# Altium schematic sheet [04] - USER IO, LEDs.SchDoc("[04] - USER IO, LEDs")
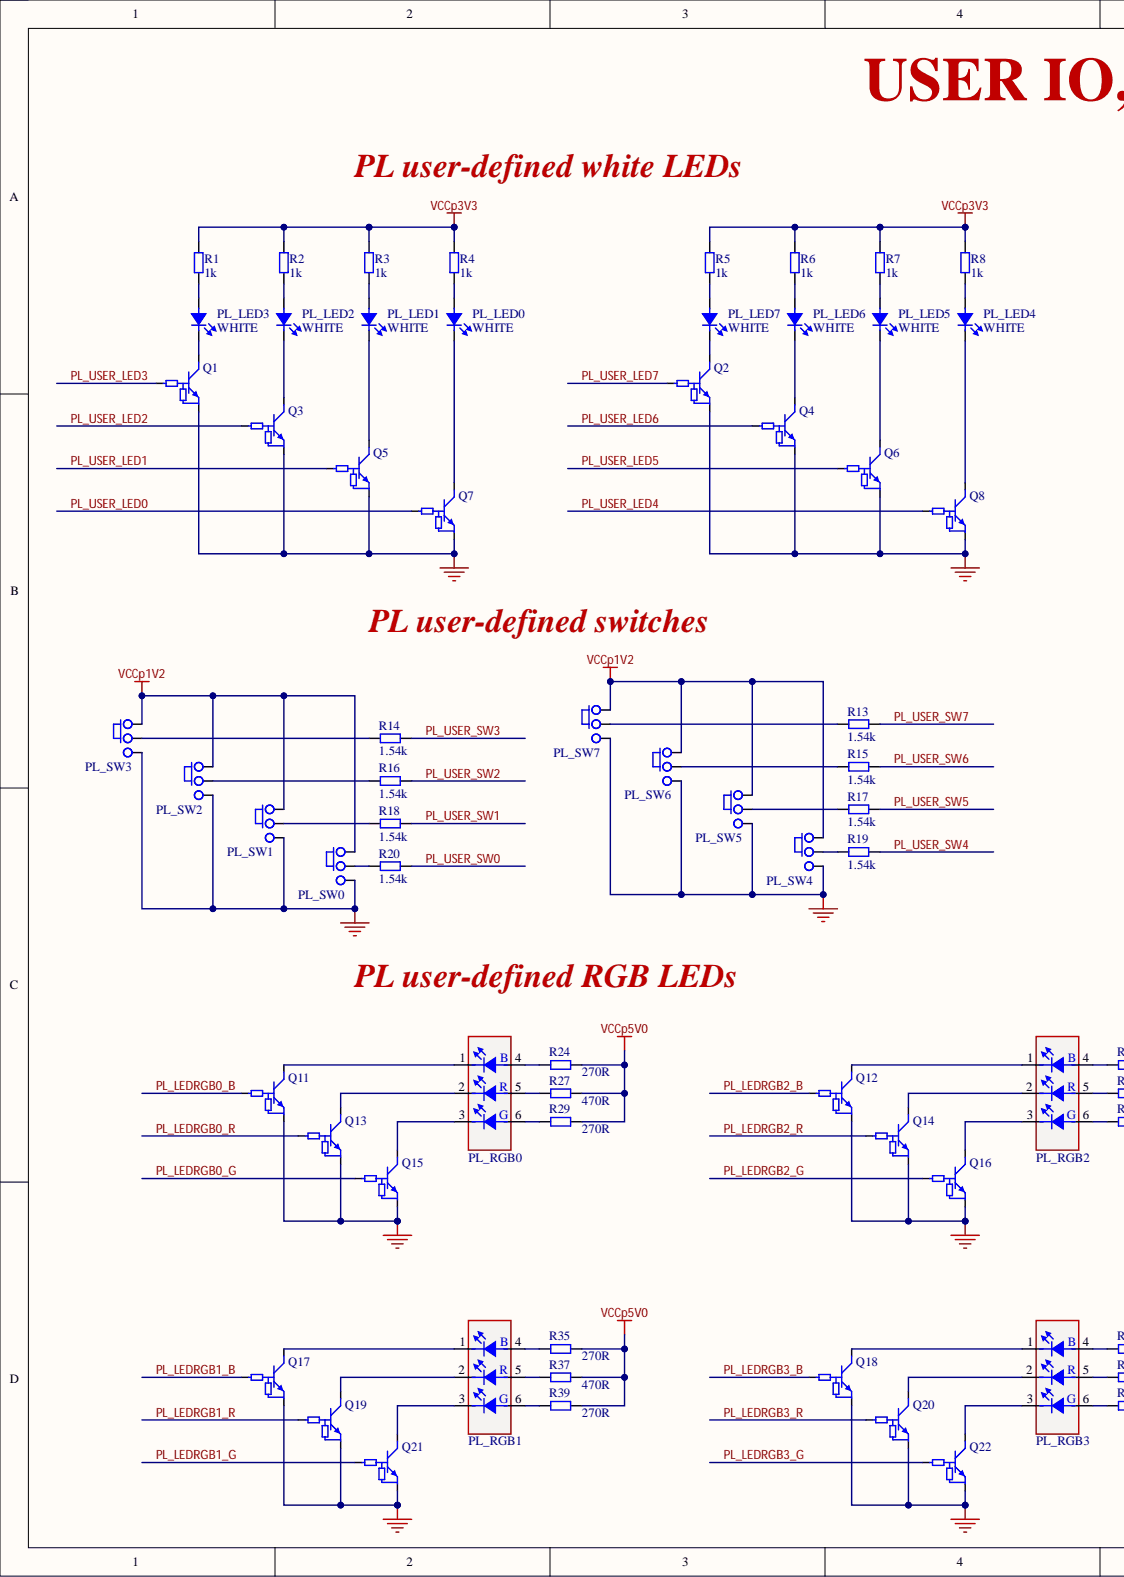
[diagram p0: [04] - USER IO, LEDs.SchDoc, left]
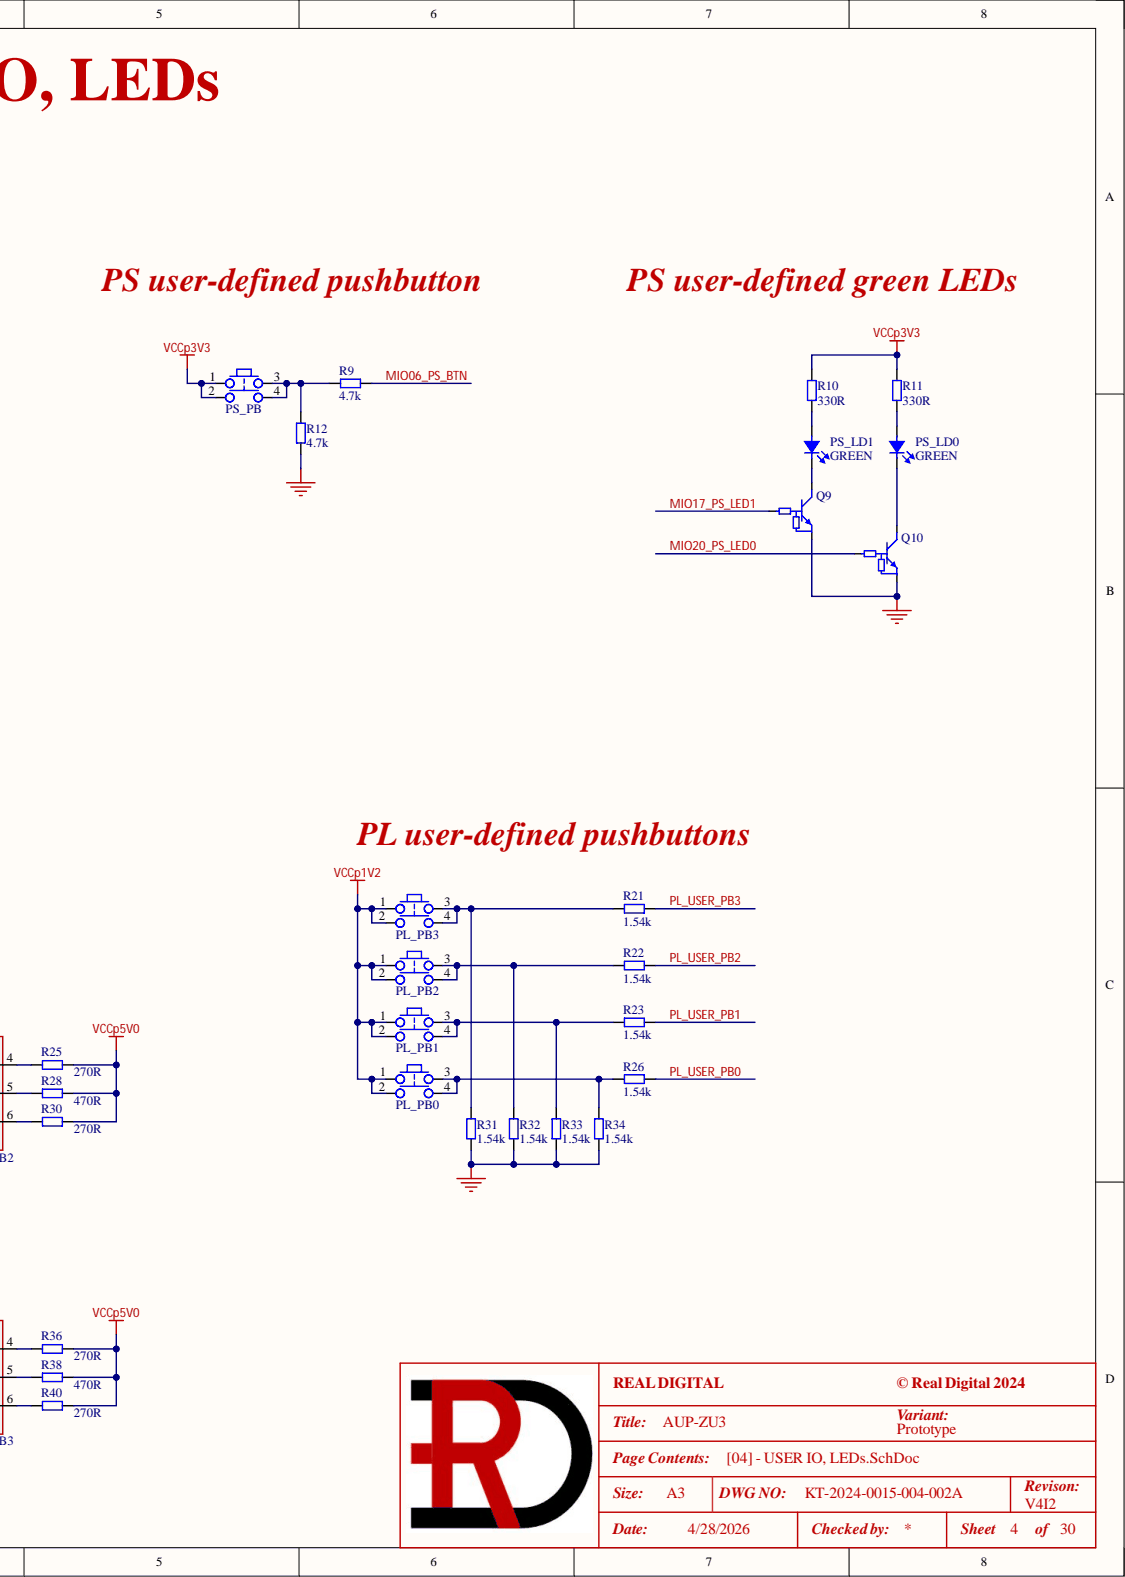
[diagram p1: [04] - USER IO, LEDs.SchDoc, right]

## Parts
PL_LED0  WHITE  [LD0603_White]
PL_LED1  WHITE  [LD0603_White]
PL_LED2  WHITE  [LD0603_White]
PL_LED3  WHITE  [LD0603_White]
PL_LED4  WHITE  [LD0603_White]
PL_LED5  WHITE  [LD0603_White]
PL_LED6  WHITE  [LD0603_White]
PL_LED7  WHITE  [LD0603_White]
PL_PB0  PTA-142  [PTA-142]  (value not drawn)
PL_PB1  PTA-142  [PTA-142]  (value not drawn)
PL_PB2  PTA-142  [PTA-142]  (value not drawn)
PL_PB3  PTA-142  [PTA-142]  (value not drawn)
PL_RGB0  VS NRD8  [LD_RGB_NRD8]  (value not drawn)
PL_RGB1  VS NRD8  [LD_RGB_NRD8]  (value not drawn)
PL_RGB2  VS NRD8  [LD_RGB_NRD8]  (value not drawn)
PL_RGB3  VS NRD8  [LD_RGB_NRD8]  (value not drawn)
PL_SW0  Switch  [SW-SS12F20G4-10.5-HA-G]  (value not drawn)
PL_SW1  Switch  [SW-SS12F20G4-10.5-HA-G]  (value not drawn)
PL_SW2  Switch  [SW-SS12F20G4-10.5-HA-G]  (value not drawn)
PL_SW3  Switch  [SW-SS12F20G4-10.5-HA-G]  (value not drawn)
PL_SW4  Switch  [SW-SS12F20G4-10.5-HA-G]  (value not drawn)
PL_SW5  Switch  [SW-SS12F20G4-10.5-HA-G]  (value not drawn)
PL_SW6  Switch  [SW-SS12F20G4-10.5-HA-G]  (value not drawn)
PL_SW7  Switch  [SW-SS12F20G4-10.5-HA-G]  (value not drawn)
PS_LD0  GREEN  [LD0603_Green]
PS_LD1  GREEN  [LD0603_Green]
PS_PB  PTA-142  [PTA-142]  (value not drawn)
Q1  DTC043ZEBTL  [SC89-EMT3F]  (value not drawn)
Q2  DTC043ZEBTL  [SC89-EMT3F]  (value not drawn)
Q3  DTC043ZEBTL  [SC89-EMT3F]  (value not drawn)
Q4  DTC043ZEBTL  [SC89-EMT3F]  (value not drawn)
Q5  DTC043ZEBTL  [SC89-EMT3F]  (value not drawn)
Q6  DTC043ZEBTL  [SC89-EMT3F]  (value not drawn)
Q7  DTC043ZEBTL  [SC89-EMT3F]  (value not drawn)
Q8  DTC043ZEBTL  [SC89-EMT3F]  (value not drawn)
Q9  DTC043ZEBTL  [SC89-EMT3F]  (value not drawn)
Q10  DTC043ZEBTL  [SC89-EMT3F]  (value not drawn)
Q11  DTC043ZEBTL  [SC89-EMT3F]  (value not drawn)
Q12  DTC043ZEBTL  [SC89-EMT3F]  (value not drawn)
Q13  DTC043ZEBTL  [SC89-EMT3F]  (value not drawn)
Q14  DTC043ZEBTL  [SC89-EMT3F]  (value not drawn)
Q15  DTC043ZEBTL  [SC89-EMT3F]  (value not drawn)
Q16  DTC043ZEBTL  [SC89-EMT3F]  (value not drawn)
Q17  DTC043ZEBTL  [SC89-EMT3F]  (value not drawn)
Q18  DTC043ZEBTL  [SC89-EMT3F]  (value not drawn)
Q19  DTC043ZEBTL  [SC89-EMT3F]  (value not drawn)
Q20  DTC043ZEBTL  [SC89-EMT3F]  (value not drawn)
Q21  DTC043ZEBTL  [SC89-EMT3F]  (value not drawn)
Q22  DTC043ZEBTL  [SC89-EMT3F]  (value not drawn)
R1  1k  [R0402]
R2  1k  [R0402]
R3  1k  [R0402]
R4  1k  [R0402]
R5  1k  [R0402]
R6  1k  [R0402]
R7  1k  [R0402]
R8  1k  [R0402]
R9  4.7k  [R0402]
R10  330R  [R0402]
R11  330R  [R0402]
R12  4.7k  [R0402]
R13  1.54k  [R0402]
R14  1.54k  [R0402]
R15  1.54k  [R0402]
R16  1.54k  [R0402]
R17  1.54k  [R0402]
R18  1.54k  [R0402]
R19  1.54k  [R0402]
R20  1.54k  [R0402]
R21  1.54k  [R0402]
R22  1.54k  [R0402]
R23  1.54k  [R0402]
R24  270R  [R0402]
R25  270R  [R0402]
R26  1.54k  [R0402]
R27  470R  [R0402]
R28  470R  [R0402]
R29  270R  [R0402]
R30  270R  [R0402]
R31  1.54k  [R0402]
R32  1.54k  [R0402]
R33  1.54k  [R0402]
R34  1.54k  [R0402]
R35  270R  [R0402]
R36  270R  [R0402]
R37  470R  [R0402]
R38  470R  [R0402]
R39  270R  [R0402]
R40  270R  [R0402]

## Nets
GND: PL_SW0.3 PL_SW1.3 PL_SW2.3 PL_SW3.3 PL_SW4.3 PL_SW5.3 PL_SW6.3 PL_SW7.3 Q1.GND Q2.GND Q3.GND Q4.GND Q5.GND Q6.GND Q7.GND Q8.GND Q9.GND Q10.GND Q11.GND Q12.GND Q13.GND Q14.GND Q15.GND Q16.GND Q17.GND Q18.GND Q19.GND Q20.GND Q21.GND Q22.GND R12.1 R31.1 R32.1 R33.1 R34.1
VCCp1V2: PL_PB0.1 PL_PB0.2 PL_PB1.1 PL_PB1.2 PL_PB2.1 PL_PB2.2 PL_PB3.1 PL_PB3.2 PL_SW0.1 PL_SW1.1 PL_SW2.1 PL_SW3.1 PL_SW4.1 PL_SW5.1 PL_SW6.1 PL_SW7.1
VCCp3V3: PS_PB.1 PS_PB.2 R1.2 R2.2 R3.2 R4.2 R5.2 R6.2 R7.2 R8.2 R10.2 R11.2
VCCp5V0: R24.2 R25.2 R27.2 R28.2 R29.2 R30.2 R35.2 R36.2 R37.2 R38.2 R39.2 R40.2
MIO06_PS_BTN: R9.2
MIO17_PS_LED1: Q9.IN
MIO20_PS_LED0: Q10.IN
PL_LEDRGB0_B: Q11.IN
PL_LEDRGB0_G: Q15.IN
PL_LEDRGB0_R: Q13.IN
PL_LEDRGB1_B: Q17.IN
PL_LEDRGB1_G: Q21.IN
PL_LEDRGB1_R: Q19.IN
PL_LEDRGB2_B: Q12.IN
PL_LEDRGB2_G: Q16.IN
PL_LEDRGB2_R: Q14.IN
PL_LEDRGB3_B: Q18.IN
PL_LEDRGB3_G: Q22.IN
PL_LEDRGB3_R: Q20.IN
PL_USER_LED0: Q7.IN
PL_USER_LED1: Q5.IN
PL_USER_LED2: Q3.IN
PL_USER_LED3: Q1.IN
PL_USER_LED4: Q8.IN
PL_USER_LED5: Q6.IN
PL_USER_LED6: Q4.IN
PL_USER_LED7: Q2.IN
PL_USER_PB0: R26.2
PL_USER_PB1: R23.2
PL_USER_PB2: R22.2
PL_USER_PB3: R21.2
PL_USER_SW0: R20.2
PL_USER_SW1: R18.2
PL_USER_SW2: R16.2
PL_USER_SW3: R14.2
PL_USER_SW4: R19.2
PL_USER_SW5: R17.2
PL_USER_SW6: R15.2
PL_USER_SW7: R13.2
NetPL_LED0_A: PL_LED0.A R4.1
NetPL_LED0_K: PL_LED0.K Q7.OUT
NetPL_LED1_A: PL_LED1.A R3.1
NetPL_LED1_K: PL_LED1.K Q5.OUT
NetPL_LED2_A: PL_LED2.A R2.1
NetPL_LED2_K: PL_LED2.K Q3.OUT
NetPL_LED3_A: PL_LED3.A R1.1
NetPL_LED3_K: PL_LED3.K Q1.OUT
NetPL_LED4_A: PL_LED4.A R8.1
NetPL_LED4_K: PL_LED4.K Q8.OUT
NetPL_LED5_A: PL_LED5.A R7.1
NetPL_LED5_K: PL_LED5.K Q6.OUT
NetPL_LED6_A: PL_LED6.A R6.1
NetPL_LED6_K: PL_LED6.K Q4.OUT
NetPL_LED7_A: PL_LED7.A R5.1
NetPL_LED7_K: PL_LED7.K Q2.OUT
NetPL_PB0_3: PL_PB0.3 PL_PB0.4 R26.1 R34.2
NetPL_PB1_3: PL_PB1.3 PL_PB1.4 R23.1 R33.2
NetPL_PB2_3: PL_PB2.3 PL_PB2.4 R22.1 R32.2
NetPL_PB3_3: PL_PB3.3 PL_PB3.4 R21.1 R31.2
NetPL_RGB0_1: PL_RGB0.1 Q11.OUT
NetPL_RGB0_2: PL_RGB0.2 Q13.OUT
NetPL_RGB0_3: PL_RGB0.3 Q15.OUT
NetPL_RGB0_4: PL_RGB0.4 R24.1
NetPL_RGB0_5: PL_RGB0.5 R27.1
NetPL_RGB0_6: PL_RGB0.6 R29.1
NetPL_RGB1_1: PL_RGB1.1 Q17.OUT
NetPL_RGB1_2: PL_RGB1.2 Q19.OUT
NetPL_RGB1_3: PL_RGB1.3 Q21.OUT
NetPL_RGB1_4: PL_RGB1.4 R35.1
NetPL_RGB1_5: PL_RGB1.5 R37.1
NetPL_RGB1_6: PL_RGB1.6 R39.1
NetPL_RGB2_1: PL_RGB2.1 Q12.OUT
NetPL_RGB2_2: PL_RGB2.2(R) Q14.OUT
NetPL_RGB2_3: PL_RGB2.3(G) Q16.OUT
NetPL_RGB2_4: PL_RGB2.4 R25.1
NetPL_RGB2_5: PL_RGB2.5 R28.1
NetPL_RGB2_6: PL_RGB2.6 R30.1
NetPL_RGB3_1: PL_RGB3.1 Q18.OUT
NetPL_RGB3_2: PL_RGB3.2(R) Q20.OUT
NetPL_RGB3_3: PL_RGB3.3(G) Q22.OUT
NetPL_RGB3_4: PL_RGB3.4 R36.1
NetPL_RGB3_5: PL_RGB3.5 R38.1
NetPL_RGB3_6: PL_RGB3.6 R40.1
NetPL_SW0_2: PL_SW0.2 R20.1
NetPL_SW1_2: PL_SW1.2 R18.1
NetPL_SW2_2: PL_SW2.2 R16.1
NetPL_SW3_2: PL_SW3.2 R14.1
NetPL_SW4_2: PL_SW4.2 R19.1
NetPL_SW5_2: PL_SW5.2 R17.1
NetPL_SW6_2: PL_SW6.2 R15.1
NetPL_SW7_2: PL_SW7.2 R13.1
NetPS_LD0_A: PS_LD0.A R11.1
NetPS_LD0_K: PS_LD0.K Q10.OUT
NetPS_LD1_A: PS_LD1.A R10.1
NetPS_LD1_K: PS_LD1.K Q9.OUT
NetPS_PB_3: PS_PB.3 PS_PB.4 R9.1 R12.2

## Library data of the parts
PL_LED0-PL_LED7 = LED_WHITE: LED WHITE 0603; Manufacturer 1: Victory Electronics; Manufacturer 1 Part Number: VS 2WC7M.325; .Checked_PCB: AC; .Creator: RV; C P/N: RD-121-0010; KT P/N: MT-121-0002; LoadStatus: Load; Category: Led; Component Kind: Standard; Library Name: KT-RealDigital.IntLib; .Checked_SCH: AC
PL_PB0-PL_PB3 PS_PB = Tact Switch: SWITCH TACTILE SPST-NO, 4.50mm x 4.50mm; Manufacturer 1: Pacer Technology Co., Ltd; Manufacturer 2 Part Number: TSXH-2GL; .Checked_PCB: RV; C P/N: 153-0059; LoadStatus: Load; Category: Tactile Switches; Component Kind: Standard; Library Name: KT-RealDigital.IntLib; Manufacturer 1 Part Number: PTA-142; .Checked_SCH: RV; .Creator: RV
PL_RGB0-PL_RGB3 = LED_RGB: LED RGB; Manufacturer 1: Victory Electronics; .Checked_PCB: RV; C P/N: 121-0264; LoadStatus: Load; Category: Led; Component Kind: Standard; Library Name: KT-RealDigital.IntLib; Manufacturer 1 Part Number: VS NRD8; .Checked_SCH: RV; .Creator: RV
PL_SW0-PL_SW7 = Slide_Switch_Long_Leads: Slide Switch Long Leads (7.35mm), SPDT, Thru Hole,; Manufacturer 1: SWITRONIC INDUSTRIAL CORP.; Manufacturer 1 Part Number: SS12F20G4-10.5-HA-G; .Checked_PCB: AC; .Creator: RV; C P/N: RD-153-0002; LoadStatus: Load; Category: Switches; Component Kind: Standard; Library Name: KT-RealDigital.IntLib; .Checked_SCH: AC
PS_LD0 PS_LD1 = LED_GREEN: LED GREEN 0603; Manufacturer 1: Everlight Electronics CO., LTD; Manufacturer 1 Part Number: 19-21SYGC/S530-E4/TR8; .Checked_PCB: AC; .Creator: RV; C P/N: 121-0006; LoadStatus: Load; Category: Led; Component Kind: Standard; Library Name: KT-RealDigital.IntLib; .Checked_SCH: AC
Q1-Q22 = DTC043ZEBTL: TRANS PREBIAS NPN 50V EMT3F; Manufacturer 1: Rohm Semiconductor; .Checked_PCB: RV; C P/N: RD-121-0006; LoadStatus: Load; Category: BJTs; Component Kind: Standard; Library Name: KT-RealDigital.IntLib; Manufacturer 1 Part Number: DTC043ZEBTL; .Checked_SCH: RV; .Creator: RV
R1-R8 = RC0402FR-071KL: RES SMD 1K OHM 1% 1/16W 0402; Manufacturer 1: Yageo; .Checked_PCB: RV; C P/N: RD-101-0010; LoadStatus: Load; Tolerance: 1%/TK100; Category: Resistors; Component Kind: Standard; Library Name: KT-RealDigital.IntLib; Manufacturer 1 Part Number: RC0402FR-071KL; .Checked_SCH: RV; .Creator: RV
R9 R12 = RC0402FR-074K7P: RES SMD 4.7K OHM 1% 1/16W 0402; Manufacturer 1: Yageo; .Checked_PCB: AC; .Creator: RV; C P/N: 101-0129; LoadStatus: Load; Tolerance: 1%/TK100; Category: Resistors; Component Kind: Standard; Library Name: KT-RealDigital.IntLib; Manufacturer 1 Part Number: RC0402FR-074K7P; .Checked_SCH: AC
R10 R11 = RC0402JR-07330RL: RES SMD 330 OHM 5% 1/16W 0402; Manufacturer 1: Yageo; .Checked_PCB: AC; .Creator: RV; C P/N: 101-0112; LoadStatus: Load; Tolerance: 5%/TK100; Category: Resistors; Component Kind: Standard; Library Name: KT-RealDigital.IntLib; Manufacturer 1 Part Number: RC0402JR-07330RL; .Checked_SCH: AC
R13-R23 R26 R31-R34 = RC0402FR-071K54L: RES SMD 1.54K OHM 1% 1/16W 0402; Manufacturer 1: Yageo; .Checked_PCB: RV; C P/N: RD-101-0015; LoadStatus: Load; Tolerance: 1%/TK100; Category: Resistors; Component Kind: Standard; Library Name: KT-RealDigital.IntLib; Manufacturer 1 Part Number: RC0402FR-071K54L; .Checked_SCH: RV; .Creator: RV
R24 R25 R29 R30 R35 R36 R39 R40 = RC0402FR-07270RL: RES SMD 270 OHM 1% 1/16W 0402; Manufacturer 1: Yageo; .Checked_PCB: RV; C P/N: RD-101-0025; LoadStatus: Load; Tolerance: 1%/TK100; Category: Resistors; Component Kind: Standard; Library Name: KT-RealDigital.IntLib; Manufacturer 1 Part Number: RC0402FR-07270RL; .Checked_SCH: RV; .Creator: RV
R27 R28 R37 R38 = RC0402JR-07470RL: RES SMD 470 OHM 5% 1/16W 0402; Manufacturer 1: Yageo; .Checked_PCB: AC; .Creator: RV; C P/N: 101-0052; LoadStatus: Load; Tolerance: 5%/TK100; Category: Resistors; Component Kind: Standard; Library Name: KT-RealDigital.IntLib; Manufacturer 1 Part Number: RC0402JR-07470RL; .Checked_SCH: AC
